# Altium schematic sheet Sensor_Box_Hub_SAMD21.SchDoc
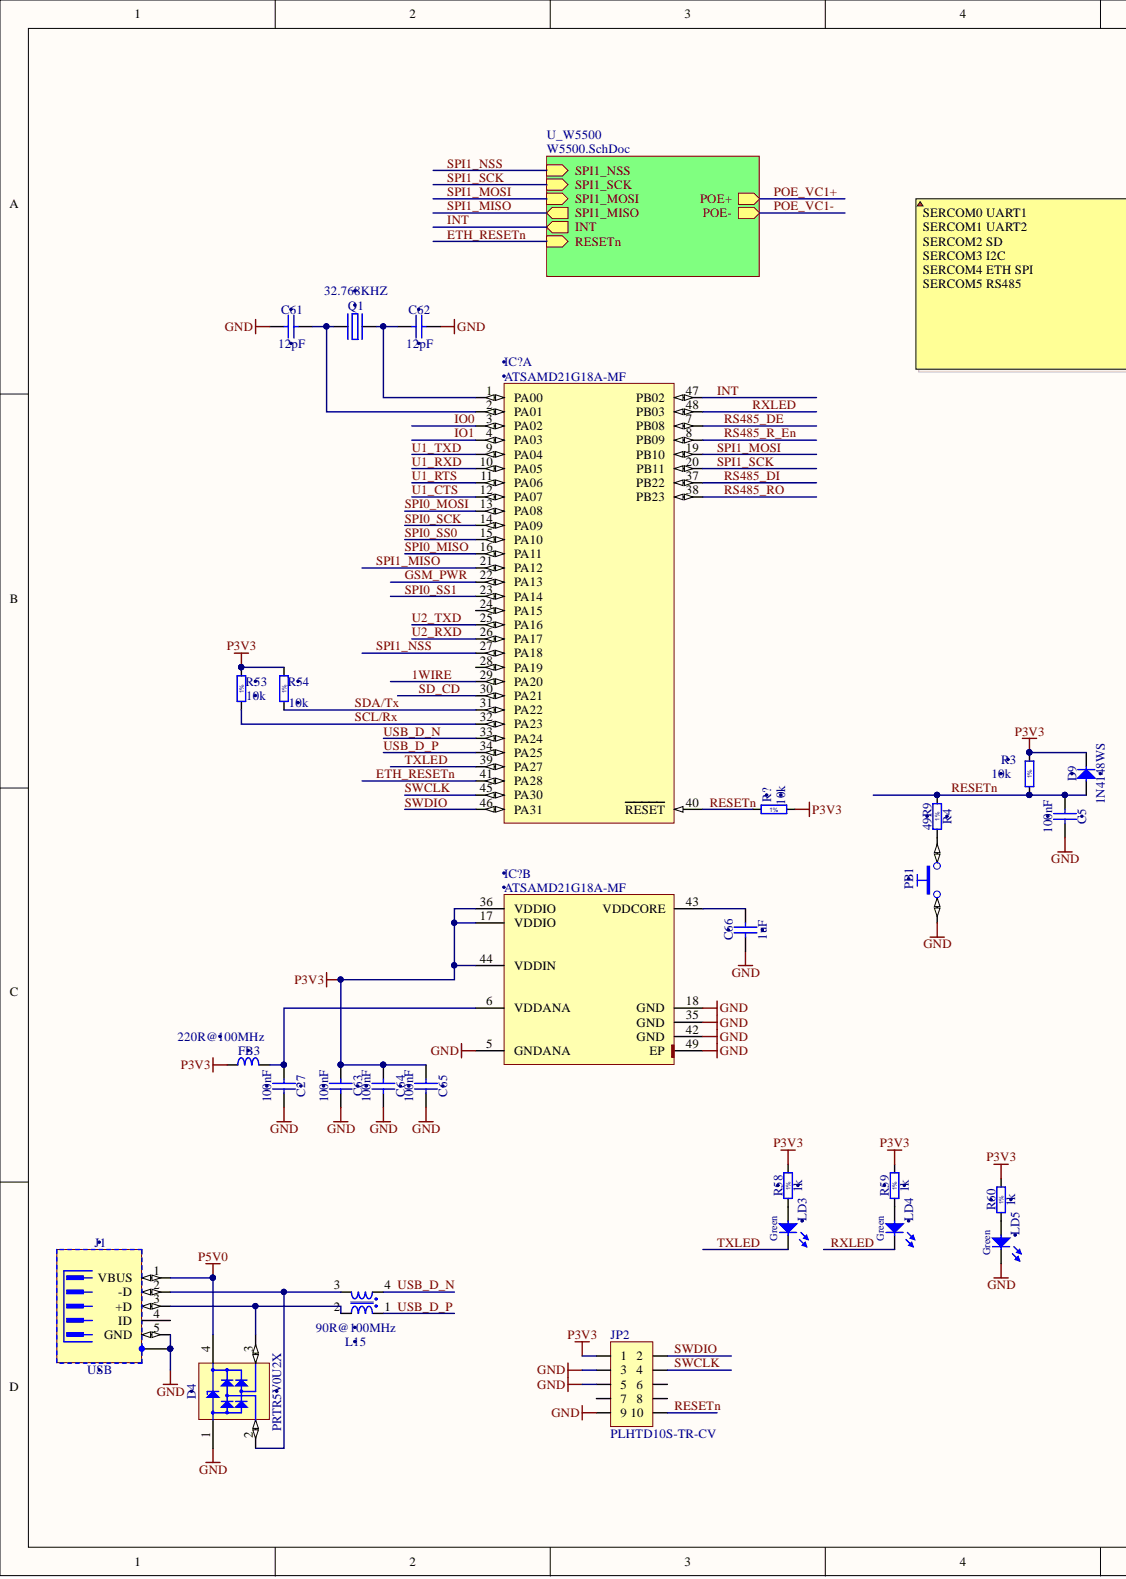
[diagram p0: Sensor_Box_Hub_SAMD21.SchDoc, left]
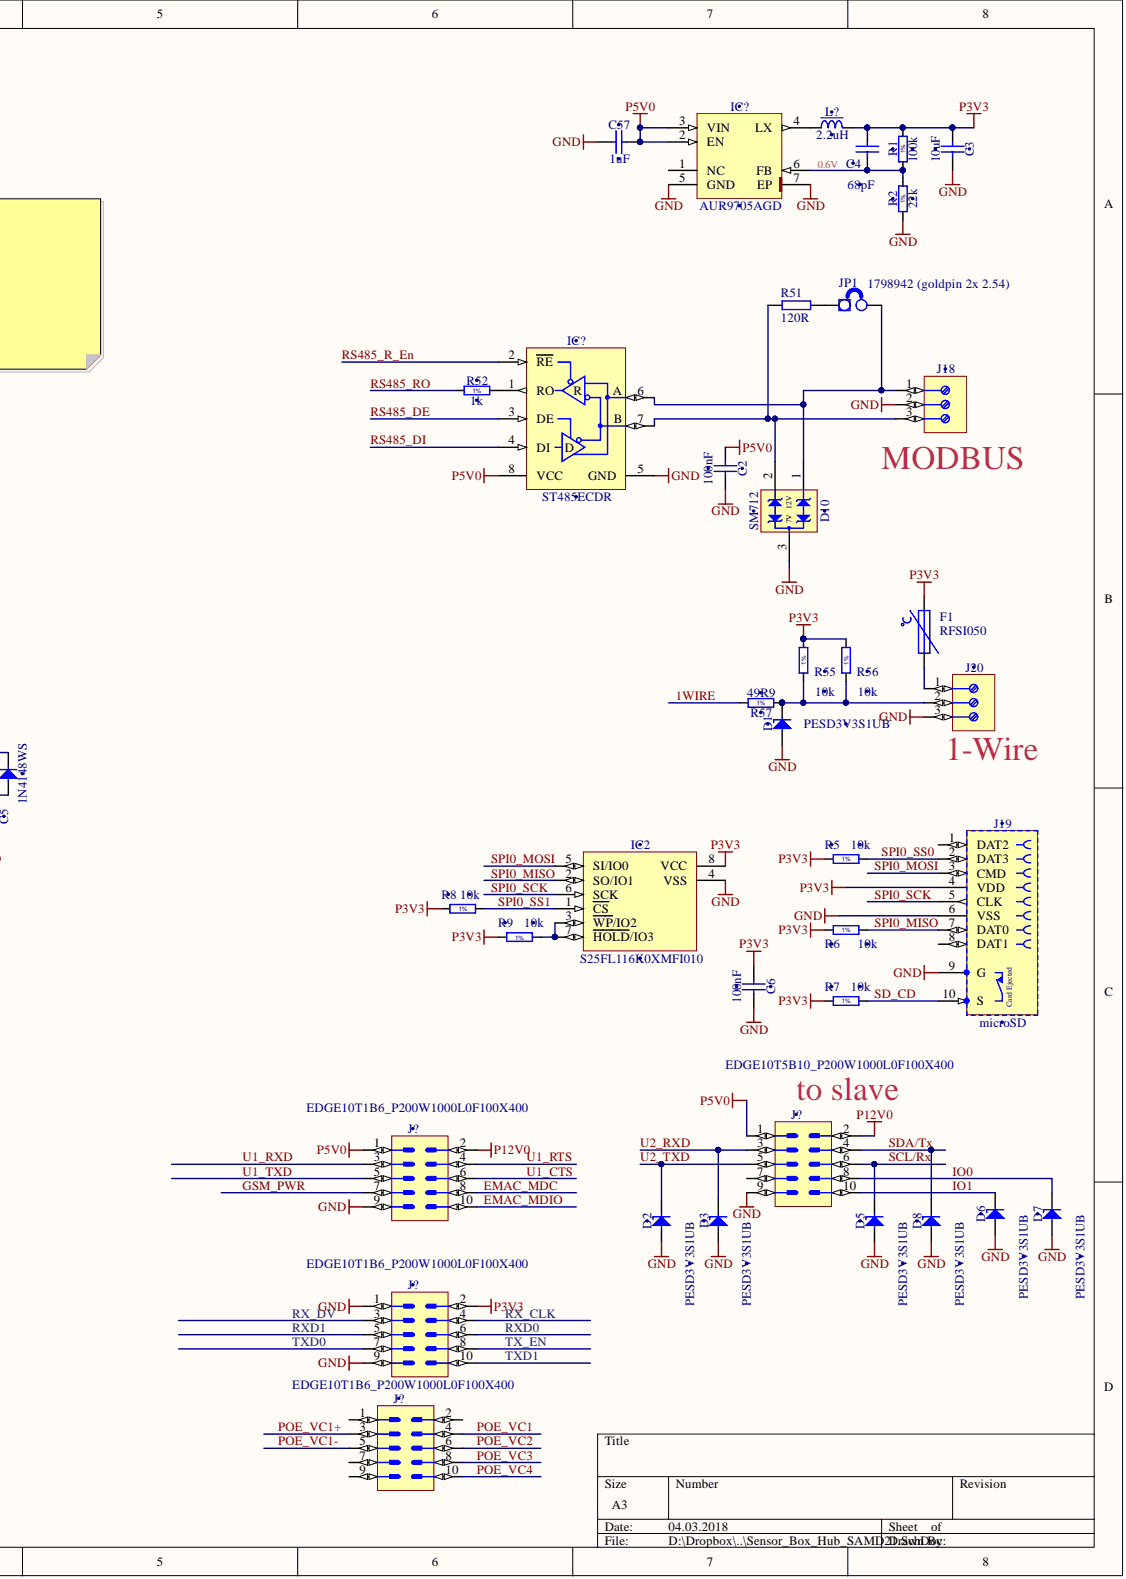
[diagram p1: Sensor_Box_Hub_SAMD21.SchDoc, right]

## Parts
C2  100nF  [CAPC1005X55N]
C3  10uF  [CAPC2012X135N]
C4  68pF  [CAPC1608X87N]
C5  100nF  [CAPC1005X55N]
C6  100nF  [CAPC1005X55N]
C27  100nF  [CAPC1005X55N]
C57  1uF  [CAPC1005X55N]
C61  12pF  [CAPC1005X55N]
C62  12pF  [CAPC1005X55N]
C63  100nF  [CAPC1005X55N]
C64  100nF  [CAPC1005X55N]
C65  100nF  [CAPC1005X55N]
C66  1uF  [CAPC1608X87N]
D1  PESD3V3S1UB  [SODFL1608X65N]
D2  PESD3V3S1UB  [SODFL1608X65N]
D3  PESD3V3S1UB  [SODFL1608X65N]
D4  PRTR5V0U2X  [SOT190P230X110-4N]
D5  PESD3V3S1UB  [SODFL1608X65N]
D6  PESD3V3S1UB  [SODFL1608X65N]
D7  PESD3V3S1UB  [SODFL1608X65N]
D8  PESD3V3S1UB  [SODFL1608X65N]
D9  1N4148WS  [SODFL2512X100N]
D10  SM712  [SOT95P237X112-3N]
F1  RFSI050  [0805R]
FB3  220R@100MHz  [INDC2012X105N]
IC2  S25FL116K0XMFI010  [SOIC127P790X216-8N]
IC?  ATSAMD21G18A-MF  [QFN50P700X700X100-49N-S525]  (parts A,B)
IC?
J1  USB  [MOLEX_105133-0011]
J18  Family  [PHOENIX_1725669]  (value not drawn)
J19  microSD  [ATTEND_112A-TAAR-R03]
J20  Family  [PHOENIX_1725669]  (value not drawn)
J?  Family  [EDGE10T5B10_P200W1000L0F100X400]  (value not drawn)  (no BOM)
J?  Family  [EDGE10T1B6_P200W1000L0F100X400]  (value not drawn)  (no BOM)
J?  Family  [EDGE10T1B6_P200W1000L0F100X400]  (value not drawn)  (no BOM)
J?  Family  [EDGE10T1B6_P200W1000L0F100X400]  (value not drawn)  (no BOM)
JP1  1798942 (goldpin 2x 2.54)  Supplier Part Number 1: 1798942  [jump]
JP2  PLHTD10S-TR-CV  [PLHTD10S-TR-CV]
L15  90R@100MHz  [IND_MURATA_DLW21S]
L?  2.2uH  [IND_MURATA_LQH44PN_P0]
LD3  Green  [LED_KINGBRIGHT_KPTR3216]
LD4  Green  [LED_KINGBRIGHT_KPTR3216]
LD5  Green  [LED_KINGBRIGHT_KPTR3216]
PB1  Push Button  [PB_TYCO_FSMSM]  (value not drawn)
Q1  32.768KHZ  [XTAL_ABRACON_ABS09]
R1  100k  [RESC1005X40N]
R2  22k  [RESC1005X40N]
R3  10k  [RESC1005X40N]
R4  49R9  [RESC1005X40N]
R5  10k  [RESC1005X40N]
R6  10k  [RESC1005X40N]
R7  10k  [RESC1005X40N]
R8  10k  [RESC1005X40N]
R9  10k  [RESC1005X40N]
R51  120R  [1206R]
R52  1k  [RESC1005X40N]
R53  10k  [RESC1005X40N]
R54  10k  [RESC1005X40N]
R55  10k  [RESC1005X40N]
R56  10k  [RESC1005X40N]
R57  49R9  [RESC1005X40N]
R58  1k  [RESC1005X40N]
R59  1k  [RESC1005X40N]
R60  1k  [RESC1005X40N]
R?  10k  [RESC1005X40N]

## Nets
GND: C2.2 C3.2 C5.2 C6.2 C27.2 C57.1 C61.1 C62.2 C63.2 C64.2 C65.2 C66.1 D1.2 D2.2 D3.2 D4.1 D5.2 D6.2 D7.2 D8.2 D10.3 IC2.4(VSS) IC?.5 IC?.7 IC?.18(GND) IC?.35(GND) IC?.42(GND) IC?.49(EP) J1.5(GND) J1.6 J18.2 J19.6(VSS) J19.9(G) J20.3 J?.1 J?.9 JP2.3 JP2.5 JP2.9 LD5.1 PB1.1 R2.1
P3V3: C3.1 C4.2 C6.1 C63.1 C64.1 C65.1 D9.1 F1.2 FB3.1 IC2.8(VCC) IC?.17(VDDIO) IC?.36(VDDIO) IC?.44(VDDIN) J19.4(VDD) J?.2 JP2.1 L?.2 R1.2 R3.2 R5.2 R6.2 R7.2 R8.2 R9.2 R53.2 R54.2 R55.2 R56.2 R58.2 R59.2 R60.2 R?.1
P5V0: C2.1 C57.2 D4.4 IC?.2 IC?.3 IC?.8 J1.1(VBUS) J?.1
P12V0: J?.2
1WIRE: IC?.29(PA20) R57.2
EMAC_MDC: J?.8
EMAC_MDIO: J?.10
ETH_RESETn: IC?.41(PA28)
GSM_PWR: IC?.22(PA13) J?.7
INT: IC?.47(PB02)
IO0: D7.1 IC?.3 J?.8
IO1: D6.1 IC?.4 J?.10
POE_VC1: J?.4
POE_VC1+: J?.3
POE_VC1-: J?.5
POE_VC2: J?.6
POE_VC3: J?.8
POE_VC4: J?.10
RESETn: C5.1 D9.2 IC?.40(RESET) JP2.10 R3.1 R4.1 R?.2
RS485_DE: IC?.3 IC?.7
RS485_DI: IC?.4 IC?.37(PB22)
RS485_R_En: IC?.2 IC?.8
RS485_RO: IC?.38(PB23) R52.1
RX_CLK: J?.4
RX_DV: J?.3
RXD0: J?.6
RXD1: J?.5
RXLED: IC?.48(PB03) LD4.1
SCL/Rx: D5.1 IC?.32(PA23) J?.6 R53.1
SD_CD: IC?.30(PA21) J19.10(S) R7.1
SDA/Tx: D8.1 IC?.31(PA22) J?.4 R54.1
SPI0_MISO: IC2.2(SO/IO1) IC?.16(PA11) J19.7(DAT0) R6.1
SPI0_MOSI: IC2.5(SI/IO0) IC?.13(PA08) J19.3(CMD)
SPI0_SCK: IC2.6(SCK) IC?.14(PA09) J19.5(CLK)
SPI0_SS0: IC?.15(PA10) J19.2(DAT3) R5.1
SPI0_SS1: IC2.1(CS) IC?.23(PA14) R8.1
SPI1_MISO: IC?.21(PA12)
SPI1_MOSI: IC?.19(PB10)
SPI1_NSS: IC?.27(PA18)
SPI1_SCK: IC?.20(PB11)
SWCLK: IC?.45(PA30) JP2.4
SWDIO: IC?.46(PA31) JP2.2
TX_EN: J?.8
TXD0: J?.7
TXD1: J?.10
TXLED: IC?.39(PA27) LD3.1
U1_CTS: IC?.12(PA07) J?.6
U1_RTS: IC?.11(PA06) J?.4
U1_RXD: IC?.10(PA05) J?.3
U1_TXD: IC?.9(PA04) J?.5
U2_RXD: D3.1 IC?.26(PA17) J?.3
U2_TXD: D2.1 IC?.25(PA16) J?.5
USB_D_N: IC?.33(PA24) L15.4
USB_D_P: IC?.34(PA25) L15.1
NetC4_1: C4.1 IC?.6 R1.1 R2.2
NetC27_1: C27.1 FB3.2 IC?.6
NetC61_2: C61.2 IC?.2 Q1.1
NetC62_1: C62.1 IC?.1 Q1.2
NetC66_2: C66.2 IC?.43(VDDCORE)
NetD1_1: D1.1 J20.2 R55.1 R56.1 R57.1
NetD4_2: D4.2 J1.2(-D) L15.3
NetD4_3: D4.3 J1.3(+D) L15.2
NetD10_1: D10.1 IC?.6 J18.1 JP1.2
NetD10_2: D10.2 IC?.7 J18.3 R51.1
NetF1_1: F1.1 J20.1
NetIC2_3: IC2.3(WP/IO2) IC2.7(HOLD/IO3) R9.1
NetIC?_1: IC?.1 R52.2
NetIC?_4: IC?.4 L?.1
NetIC?_24: IC?.24(PA15)
NetIC?_28: IC?.28(PA19)
NetJ1_4: J1.4(ID)
NetJ19_1: J19.1(DAT2)
NetJ19_8: J19.8(DAT1)
NetJ?_1: J?.1
NetJ?_2: J?.2
NetJ?_7: J?.7
NetJ?_9: J?.9
NetJP1_1: JP1.1 R51.2
NetJP2_6: JP2.6
NetJP2_7: JP2.7
NetJP2_8: JP2.8
NetLD3_2: LD3.2 R58.1
NetLD4_2: LD4.2 R59.1
NetLD5_2: LD5.2 R60.1
NetPB1_2: PB1.2 R4.2

## Library data of the parts
C2 C5 C6 C27 C63-C65 = CC0402_100NF_16V_10%_X7R: Non-polarised capacitor; Manufacturer: GENERIC; Manufacturer1 Part Number: GRM155R71C104KA88D; Part Description: SMD Multilayer Chip Ceramic Capacitor; Database Table Name: Capacitors SMD; TC: X7R; Tolerance: ±10%; Voltage: 16V; Case: 0402; Component Kind: Standard; Component Type: Standard; CreateDate: 05.12.2013; HelpURL: \\cern.ch\dfs\Applications\Altium\Datasheets\CC0402_X7R_MURATA_GRM.pdf; LatestRevisionDate: 03.12.2007; Library Name: Capacitors.DbLib; Manufacturer1 ComponentHeight: 0.55mm; Manufacturer1 Example: MURATA; Manufacturer Part Number: CC0402_100NF_16V_10%_X7R; Mounted: Yes; Part Number: CC0402_100NF_16V_10%_X7R; Pin Count: 2; Sense: No; SMD: Yes; Socket: No; Status: Not Recommended; Value: 100nF; Author: CERN DEM JLC
C3 = CC0805_10UF_16V_10%_X5R: Non-polarised capacitor; Manufacturer: GENERIC; Manufacturer1 Part Number: C0805C106K4PAC; Part Description: SMD Multilayer Chip Ceramic Capacitor; Database Table Name: Capacitors SMD; TC: X5R; Tolerance: ±10%; Voltage: 16V; Case: 0805; Component Kind: Standard; Component Type: Standard; CreateDate: 03.12.2007; HelpURL: \\cern.ch\dfs\Applications\Altium\Datasheets\CC1206_X5R_KEMET.pdf; LatestRevisionDate: 03.12.2007; Library Name: Capacitors.DbLib; Manufacturer1 ComponentHeight: 1.4mm; Manufacturer1 Example: KEMET; Manufacturer Part Number: CC0805_10UF_16V_10%_X5R; Mounted: Yes; Part Number: CC0805_10UF_16V_10%_X5R; Pin Count: 2; Sense: No; SMD: Yes; Socket: No; Status: Preferred; Value: 10uF; Author: CERN DEM JLC
C4 = CC0603_68PF_50V_5%_NP0: Non-polarised capacitor; Manufacturer: GENERIC; Manufacturer1 Part Number: 223886715689; Part Description: SMD Multilayer Chip Ceramic Capacitor; Database Table Name: Capacitors SMD; TC: C0G/NP0; Tolerance: ±5%; Voltage: 50V; Case: 0603; Component Kind: Standard; Component Type: Standard; CreateDate: 03.12.2007; HelpURL: \\cern.ch\dfs\Applications\Altium\Datasheets\CC0603_NP0_PHYCOMP.pdf; LatestRevisionDate: 03.12.2007; Library Name: Capacitors.DbLib; Manufacturer1 ComponentHeight: 0.87mm; Manufacturer1 Example: PHYCOMP; Manufacturer Part Number: CC0603_68PF_50V_5%_NP0; Mounted: Yes; Part Number: CC0603_68PF_50V_5%_NP0; Pin Count: 2; Sense: No; SMD: Yes; Socket: No; Status: Preferred; Value: 68pF; Author: CERN DEM JLC
C57 = CC0402_1UF_16V_10%_X5R: Non-polarised capacitor; Manufacturer: GENERIC; Manufacturer1 Part Number: C1005X5R1C105KT; Part Description: SMD Multilayer Chip Ceramic Capacitor; Database Table Name: Capacitors SMD; TC: X5R; Tolerance: ±10%; Voltage: 16V; Case: 0402; Component Kind: Standard; Component Type: Standard; CreateDate: 25.10.2012; HelpURL: \\cern.ch\dfs\Applications\Altium\Datasheets\CC0402_X5R_TDK_C.pdf; LatestRevisionDate: 25.10.2012; Library Name: Capacitors.DbLib; Manufacturer1 ComponentHeight: 0.55mm; Manufacturer1 Example: TDK; Manufacturer Part Number: CC0402_1UF_16V_10%_X5R; Mounted: Yes; Part Number: CC0402_1UF_16V_10%_X5R; Pin Count: 2; Sense: No; SMD: Yes; Socket: No; Status: Preferred; Value: 1uF; Author: CERN DEM JLC
C61 C62 = CC0402_12PF_50V_5%_C0G: Non-polarised capacitor; Manufacturer: GENERIC; Manufacturer1 Part Number: C0402C120J5GACTU; Part Description: SMD Multilayer Chip Ceramic Capacitor; Database Table Name: Capacitors SMD; TC: C0G/NP0; Tolerance: ±5%; Voltage: 50V; Case: 0402; Component Kind: Standard; Component Type: Standard; CreateDate: 04.10.2016; HelpURL: \\cern.ch\dfs\Applications\Altium\Datasheets\CC0805_C0G_KEMET_(Commercial Grade).pdf; LatestRevisionDate: 04.10.2016; Library Name: Capacitors.DbLib; Manufacturer1 ComponentHeight: 0.55mm; Manufacturer1 Example: KEMET; Manufacturer Part Number: CC0402_12PF_50V_5%_C0G; Mounted: Yes; Part Number: CC0402_12PF_50V_5%_C0G; Pin Count: 2; Sense: No; SMD: Yes; Socket: No; Status: Preferred; Value: 12pF; Author: CERN DEM JLC
C66 = CC0603_1UF_16V_10%_X7R: Non-polarised capacitor; Manufacturer: GENERIC; Manufacturer1 Part Number: 223878615663; Part Description: SMD Multilayer Chip Ceramic Capacitor; Database Table Name: Capacitors SMD; TC: X7R; Tolerance: ±10%; Voltage: 16V; Case: 0603; Component Kind: Standard; Component Type: Standard; CreateDate: 03.12.2007; HelpURL: \\cern.ch\dfs\Applications\Altium\Datasheets\CC0603_X7R_PHYCOMP.pdf; LatestRevisionDate: 17.04.2015; Library Name: Capacitors.DbLib; Manufacturer1 ComponentHeight: 0.9mm; Manufacturer1 Example: YAGEO PHYCOMP; Manufacturer Part Number: CC0603_1uF_16V_10%_X7R; Mounted: Yes; Part Number: CC0603_1UF_16V_10%_X7R; Pin Count: 2; Sense: No; SMD: Yes; Socket: No; Status: None; Value: 1uF; Author: CERN DEM JLC
D1-D3 D5-D8 = PESD3V3S1UB: ESD protection diode; Manufacturer: NXP SEMICONDUCTORS; Part Description: ESD protection diode (23kV); Bonding: No; Database Table Name: Diodes; Power: 330W; PressFit: No; Voltage: 3V3; Case: SOD523; ComponentHeight: 0.65mm; Component Kind: Standard; Component Type: Standard; CreateDate: 29.11.2012; Device: PESD3V3S1UB; HelpURL: \\cern.ch\dfs\Applications\Altium\Datasheets\PESD3V3S1UB.pdf; LatestRevisionDate: 29.11.2012; Library Name: ICs And Semiconductors.DbLib; Manufacturer Part Number: PESD3V3S1UB; Mounted: Yes; PackageDescription: Ultra Small Outline Diode Flat Lead (SOD-523), Body; 1.2mm L X 0.8mm W X 0.65mm H, IPC Medium Density; Part Number: PESD3V3S1UB; Pin Count: 2; Sense: No; SMD: Yes; Socket: No; Author: CERN DEM JLC
D4 = PRTR5V0U2X: Ultra Low Capacitance Double Rail-to-Rail ESD Protection Diode; Manufacturer: NXP SEMICONDUCTORS; Part Description: Ultra Low Capacitance (1pF) Double Rail-to-Rail ESD Protection Diode (8kV); Bonding: No; Database Table Name: Diodes; PressFit: No; Voltage: 5.5V; Case: SOT143B; ComponentHeight: 1.1mm; Component Kind: Standard; Component Type: Standard; CreateDate: 2011-03-22; Device: PRTR5V0U2X; HelpURL: \\cern.ch\dfs\Applications\Altium\Datasheets\PRTR5V0U2X.pdf; LatestRevisionDate: 2011-03-22; Library Name: ICs And Semiconductors.DbLib; Manufacturer Part Number: PRTR5V0U2X; Mounted: Yes; PackageDescription: SOT143B, SOT, 1.90mm Pitch; 4 Pin, 1.30mm W X 2.90mm L X 1.10mm H body, IPC Medium Density; Part Number: PRTR5V0U2X; Pin Count: 4; SMD: Yes; Socket: No; Table: Diodes; Author: CERN DEM JLC
D9 = 1N4148WS: Diode; Manufacturer: FAIRCHILD SEMICONDUCTOR; Part Description: Small Signal Diodes; Bonding: No; Database Table Name: Diodes; Power: 150mA; PressFit: No; Voltage: 75V; Case: SOD-323F; ComponentHeight: 1mm; Component Kind: Standard; Component Type: Standard; CreateDate: 12.05.2011; Device: 1N4148WS; HelpURL: \\cern.ch\dfs\Applications\Altium\Datasheets\1N4148WS.pdf; LatestRevisionDate: 12.05.2011; Library Name: ICs And Semiconductors.DbLib; Manufacturer Part Number: 1N4148WS; Mounted: Yes; PackageDescription: SODFL Diode; 1.70mm W X 1.25mm L X 1.00mm H body, IPC Medium Density; Part Number: 1N4148WS; Pin Count: 2; Sense: No; SMD: Yes; Socket: No; Author: CERN DEM JLC
D10 = SM712: Asymmetrical TVS Diode for Extended Common-Mode RS-485; Manufacturer: SEMTECH; Bonding: No; Database Table Name: Diodes; Power: 400W; PressFit: No; Voltage: 12V/-7V; Case: SOT-23; ComponentHeight: 1.12mm; Component Kind: Standard; Component Type: Standard; CreateDate: 23.04.2012; Device: SM712; HelpURL: \\cern.ch\dfs\Applications\Altium\Datasheets\SM712.pdf; LatestRevisionDate: 23.04.2012; Library Name: ICs And Semiconductors.DbLib; Manufacturer Part Number: SM712; Mounted: Yes; PackageDescription: SOT, 0.95mm, pitch; 3 pin,1.30mm W X 2.92mm L X 1.12mm H body, IPC Medium Density; Part Description: Asymmetrical TVS Diode for Extended Common-Mode RS-485; Part Number: SM712; Pin Count: 3; Sense: No; SMD: Yes; Socket: No; Status: Obsolete; Author: CERN DEM JLC
F1 = F_PTC_0.05A: 50mA polymer FUSE; Component Kind: Standard; Library Name: LIBRARY_SCH.SCHLIB
FB3 = IND0805_MURATA_BLM21PG221SN1D: Inductor; Manufacturer: MURATA; Part Description: SMD EMIFIL Suppression Chip Ferrite Bead; Database Table Name: Inductors SMD; Power: 2A; Resistance: 0.05R; Tolerance: ±25%; Case: 0805; ComponentHeight: 1.05mm; Component Kind: Standard; Component Type: Standard; CreateDate: 2010-01-25; HelpURL: \\cern.ch\dfs\Applications\Altium\Datasheets\IND0402_MURATA_BLM21P.pdf; LatestRevisionDate: 2010-01-25; Library Name: Inductors & Transformers.DbLib; Manufacturer Part Number: BLM21PG221SN1D; Mounted: Yes; Part Number: IND0805_MURATA_BLM21PG221SN1D; Pin Count: 2; SMD: Yes; Socket: No; Status: None; Table: Inductors SMD; Value: 220R@100MHz; Author: CERN DEM JLC
IC2 = (no symbol name): 16Mb, 3V, Multiple I/O Serial NOR Flash Memory; Part Description: 16Mb, 2.7 to 3.6V, SPI Flash Memory; Bonding: No; PressFit: No; Case: SOIC8; ComponentHeight: 2.16mm; Component Kind: Standard; Component Type: Standard; CreateDate: 28.11.2011; Device: S25FL116K0XMFI010; HelpURL: \\cern.ch\dfs\Applications\Altium\Datasheets\N25Q128A13ESE40.pdf; LatestRevisionDate: 30.07.2015; Library Name: LIBRARY_SCH.SCHLIB; Manufacturer Part Number: S25FL116K0XMFI010; Mounted: Yes; PackageDescription: SOIC, 1.27mm Pitch; 8 Pin, 5.285mm W X 5.335mm L X 2.16mm H body, IPC Medium Density; Part Number: S25FL116K0XMFI010; Pin Count: 8; Sense: No; SMD: Yes; Socket: No; Author: GK
IC? = ATSAMD21G18A-MF: 32-bit ARM®-based Cortex®-M0+ core Microcontroller, 1.62V to 3.6V, 48MHz, 256KB Flash, 32KB of SRAM, 48 QFN, Industrial Grade (-40°C to 125°C); Manufacturer: MICROCHIP; Part Description: 32-bit ARM®-based Cortex®-M0+ core Microcontroller, 1.62V to 3.6V, 48MHz, 256KB Flash, 32KB SRAM, 48 QFN, Temperature Range -40°C to 125°C; Bonding: No; Database Table Name: Logic; PressFit: No; Case: QFN48; ComponentHeight: 1mm; Component Kind: Standard; Component Type: Standard; CreateDate: 23.01.2018; Device: ATSAMD21G18A-MF; HelpURL: \\cern.ch\dfs\Applications\Altium\Datasheets\ATSAMD21G18A-MF.pdf; LatestRevisionDate: 23.01.2018; Library Name: ICs And Semiconductors.DbLib; Manufacturer Part Number: ATSAMD21G18A-MF; Mounted: Yes; PackageDescription: QFN, 0.50mm Pitch, Square; 48 Pin, 7.00mm L X 7.00mm W X 1mm H Body (W/Thermal Tab 5.25mm X 5.25mm), IPC Medium Density; Part Number: ATSAMD21G18A-MF; Pin Count: 49; Sense: No; SMD: Yes; Socket: No; Author: CERN DEM BC
J1 = MOLEX_105133-0011: 5 Pin + 1 Shield USB Receptacle; Part Description: 0.65mm Pitch, Micro-USB Type B Surface Mounte Vertical Receptacle; Database Table Name: MOLEX; PressFit: No; ComponentHeight: 6.7mm; Component Kind: Standard; Component Type: Standard; CreateDate: 13.12.2017; Family: USB; HelpURL: \\cern.ch\dfs\Applications\Altium\Datasheets\MOLEX_105133-0011.pdf; LatestRevisionDate: 13.12.2017; Library Name: Connectors.DbLib; Manufacturer: MOLEX; Manufacturer Part Number: 105133-0011; Mounted: Yes; Part Number: MOLEX_105133-0011; Pin Count: 8; Sense: No; SMD: Yes; Socket: No; Status: None; Author: CERN DEM MR
J18 J20 = PHOENIX_1725669: Terminal Block, 3 Positions, Screw Connection; Manufacturer: PHOENIX CONTACT; Manufacturer Part Number: 1725669 (MPT 0,5/3-2,54); Part Description: 6A, 63V, Terminal Block, Pitch 2.54mm, 3 Positions, Screw Connection; PressFit: No; ComponentHeight: 8.5mm; Component Kind: Standard; Component Type: Standard; CreateDate: 07.11.2008; Database Table Name: PHOENIX; HelpURL: \\cern.ch\dfs\Applications\Altium\Datasheets\PHOENIX_1725669.pdf; LatestRevisionDate: 26.04.2010; Library Name: Connectors.DbLib; Mounted: Yes; Part Number: PHOENIX_1725669; Pin Count: 3; Sense: No; SMD: No; Socket: No; Status: None; Author: CERN DEM JMW
J19 = ATTEND_112A-TAAR-R03: Connector MicroSD 8 Pin + Switch; Manufacturer: ATTEND; Part Description: MicroSD Socket, Push-Push Type; Database Table Name: Miscellaneous; PressFit: No; ComponentHeight: 1.9mm; Component Kind: Standard; Component Type: Standard; CreateDate: 07.07.2015; Family: microSD; HelpURL: \\cern.ch\dfs\Applications\Altium\Datasheets\ATTEND_112A-TAAR-R03.pdf; LatestRevisionDate: 07.07.2015; Library Name: Connectors.DbLib; Manufacturer Part Number: 112A-TAAR-R03; Mounted: Yes; Part Number: ATTEND_112A-TAAR-R03; Pin Count: 10; Sense: No; SMD: Yes; Socket: No; Status: None; Author: CERN DEM JMW
J? = EDGE10T5B10_P200W1000L0F100X400: PCB Edge Connector 10 (2x5); Part Description: 10 Contacts Board Edge Connector, Pitch 2mm, Length 0mm, Width 10mm, Finger Lenght 4mm, Finger Width 1mm; Database Table Name: Miscellaneous; Mounted: No; PressFit: No; ComponentHeight: 0mm; Component Type: Standard (No BOM); CreateDate: 14.02.2018; HelpURL: \\cern.ch\dfs\Applications\Altium\Datasheets\EDGE10T5B10_P200W1000L0F100X400.pdf; LatestRevisionDate: 14.02.2018; Library Name: Connectors.DbLib; Part Number: EDGE10T5B10_P200W1000L0F100X400; Pin Count: 10; Sense: No; SMD: No; Socket: No; Status: None; Author: CERN DEM MR
J? J? J? = EDGE10T1B6_P200W1000L0F100X400: PCB Edge Connector 10 (2x5); Part Description: 10 Contacts Board Edge Connector, Pitch 2mm, Length 0mm, Width 10mm, Finger Lenght 4mm, Finger Width 1mm; Database Table Name: Miscellaneous; Mounted: No; PressFit: No; ComponentHeight: 0mm; Component Type: Standard (No BOM); CreateDate: 14.02.2018; HelpURL: \\cern.ch\dfs\Applications\Altium\Datasheets\EDGE10T1B6_P200W1000L0F100X400.pdf; LatestRevisionDate: 14.02.2018; Library Name: Connectors.DbLib; Part Number: EDGE10T1B6_P200W1000L0F100X400; Pin Count: 10; Sense: No; SMD: No; Socket: No; Status: None; Author: CERN DEM MR
JP1 = JUMPER: Component Kind: Standard; Description: Jumper; Library Name: LIBRARY_SCH.SCHLIB; Supplier 1: Farnell
JP2 = CON_2x5 1.27 SMT Male: 1.27 mm dual row goldpin (Maritex); Component Kind: Standard; Library Name: LIBRARY_SCH.SCHLIB
L15 = IND_MURATA_DLW21SN900SQ2L: Inductor Common Mode; Manufacturer: MURATA; Part Description: Wire Wound Common Mode Choke Coil (DLW21S Series); Database Table Name: Inductors SMD; Power: 330mA; Resistance: 0.35R; Tolerance: ±25%; Case: 0805; ComponentHeight: 1.4mm; Component Kind: Standard; Component Type: Standard; CreateDate: 2014-07-01; HelpURL: \\cern.ch\dfs\Applications\Altium\Datasheets\IND_MURATA_DLW21S.pdf; LatestRevisionDate: 2014-07-01; Library Name: Inductors & Transformers.DbLib; Manufacturer Part Number: DLW21SN900SQ2L; Mounted: Yes; Part Number: IND_MURATA_DLW21SN900SQ2L; Pin Count: 4; SMD: Yes; Socket: No; Status: None; Table: Inductors SMD; Value: 90R@100MHz; Author: CERN DEM JLC
L? = IND_2.2UH_20%_MURATA_LQH44PN2R2MP0L: Inductor With Core; Manufacturer: MURATA; Part Description: Wire Wound Ferrite Inductor for Power Lines; Database Table Name: Inductors SMD; Power: 1.8A; Resistance: 0R049; Tolerance: ±20%; Case: 4040; ComponentHeight: 1.8mm; Component Kind: Standard; Component Type: Standard; CreateDate: 14.02.2018; HelpURL: \\cern.ch\dfs\Applications\Altium\Datasheets\IND_MURATA_LQH44PN_P0.pdf; LatestRevisionDate: 14.02.2018; Library Name: Inductors & Transformers.DbLib; Manufacturer Part Number: LQH44PN2R2MP0L; Mounted: Yes; Part Number: IND_2.2UH_20%_MURATA_LQH44PN2R2MP0L; Pin Count: 2; Sense: No; SMD: Yes; Socket: No; Status: None; Value: 2.2uH; Author: CERN DEM JLC
LD3-LD5 = LED_KINGBRIGHT_KPTR-3216CGCK: LED Green 1A 2C; Part Description: Reverse Mount LED 1.4x1.6mm Green; Bonding: No; Database Table Name: LEDs & Displays; PressFit: No; Color: Green; ComponentHeight: 0.35mm; Component Kind: Standard; Component Type: Standard; CreateDate: 15.02.2016; HelpURL: \\cern.ch\dfs\Applications\Altium\Datasheets\LED_KINGBRIGHT_KPTR-3216CGCK.pdf; LatestRevisionDate: 15.02.2016; Library Name: ICs And Semiconductors.DbLib; Manufacturer: KINGBRIGHT; Manufacturer Part Number: KPTR-3216CGCK; Mounted: Yes; PackageDescription: Reverse Mount LED 3216, Hole 2.1x2.3mm 0.35mm Height; Part Number: LED_KINGBRIGHT_KPTR-3216CGCK; Pin Count: 2; Sense: No; SMD: Yes; Socket: No; Author: CERN DEM MR
PB1 = PB_TYCO_FSMSM: Push-Button Switch SPDT; Manufacturer: TYCO ELECTRONICS; Manufacturer Part Number: FSMSM or 1437566-3; Part Description: 12VDC 50mA SPST Tactile  Push-Button Switch; Database Table Name: Switches; PressFit: No; ComponentHeight: 4.3mm; Component Kind: Standard; Component Type: Standard; CreateDate: 30.06.2008; Family: Push Button; HelpURL: \\cern.ch\dfs\Applications\Altium\Datasheets\PB_TYCO_FSMSM.pdf; LatestRevisionDate: 21.06.2012; Library Name: Eletro-mechanical.DbLib; Mounted: Yes; PackageDescription: Body 3.5x6.0mm, Pushbutton Switch,  TYCO ALCOSWITCH FSMSM Serie; Part Number: PB_TYCO_FSMSM; Pin Count: 2; Sense: No; SMD: Yes; Socket: No; Status: None; Author: CERN DEM JLC
Q1 = XTAL_32.768KHZ_ABRACON_ABS09-32.768KHZ-T: Quartz Crystal; Part Description: Tolerance: ±20ppm, Stability: ±5ppm, -40°C to +85°C, 12.5pF, SMD Low Profile Crystal, ABS09 Series; Bonding: No; Database Table Name: Crystals & Oscillators; PressFit: No; ComponentHeight: 0.9mm; Component Kind: Standard; Component Type: Standard; CreateDate: 16.10.2014; HelpURL: \\cern.ch\dfs\Applications\Altium\Datasheets\XTAL_ABRACON_ABS09.pdf; LatestRevisionDate: 16.10.2014; Library Name: ICs And Semiconductors.DbLib; Manufacturer: ABRACON; Manufacturer Part Number: ABS09-32.768KHZ-T; Mounted: Yes; PackageDescription: 2 Pins, 4.1x1.5mm, SMD Low Profile Crystal, ABS09 Serie; Part Number: XTAL_32.768KHZ_ABRACON_ABS09-32.768KHZ-T; Pin Count: 2; Sense: No; SMD: Yes; Socket: No; Value: 32.768KHZ; Author: CERN DEM JLC
R1 = R0402_100K_1%_0.0625W_100PPM: Resistor - 1%; Manufacturer: GENERIC; Manufacturer1 Part Number: 232270671004L; Part Description: General Purpose Thick Film Chip Resistor; Database Table Name: Resistors SMD; PressFit: No; TC: ±100ppm/°C; Case: 0402; Component Kind: Standard; Component Type: Standard; CreateDate: 03.12.2007; HelpURL: \\cern.ch\dfs\Applications\Altium\Datasheets\R0402_Phycomp_RC0402.pdf; LatestRevisionDate: 17.10.2012; Library Name: Resistors.DbLib; Manufacturer1 ComponentHeight: 0.4mm; Manufacturer1 Example: YAGEO PHYCOMP; Manufacturer Part Number: R0402_100K_1%_0.0625W_100PPM; Mounted: Yes; Part Number: R0402_100K_1%_0.0625W_100PPM; Pin Count: 2; Power: 0.0625W; Sense: No; SMD: Yes; Socket: No; Status: Not Recommended; Tolerance: ±1%; Value: 100k; Author: CERN DEM JLC
R2 = R0402_22K_1%_0.0625W_100PPM: Resistor - 1%; Manufacturer: GENERIC; Manufacturer1 Part Number: 232270672203L; Part Description: General Purpose Thick Film Chip Resistor; Database Table Name: Resistors SMD; PressFit: No; TC: ±100ppm/°C; Case: 0402; Component Kind: Standard; Component Type: Standard; CreateDate: 03.12.2007; HelpURL: \\cern.ch\dfs\Applications\Altium\Datasheets\R0402_Phycomp_RC0402.pdf; LatestRevisionDate: 17.10.2012; Library Name: Resistors.DbLib; Manufacturer1 ComponentHeight: 0.4mm; Manufacturer1 Example: YAGEO PHYCOMP; Manufacturer Part Number: R0402_22K_1%_0.0625W_100PPM; Mounted: Yes; Part Number: R0402_22K_1%_0.0625W_100PPM; Pin Count: 2; Power: 0.0625W; Sense: No; SMD: Yes; Socket: No; Status: Not Recommended; Tolerance: ±1%; Value: 22k; Author: CERN DEM JLC
R3 R5-R9 R53-R56 R? = R0402_10K_1%_0.0625W_100PPM: Resistor - 1%; Manufacturer: GENERIC; Manufacturer1 Part Number: 232270671003L; Part Description: General Purpose Thick Film Chip Resistor; Database Table Name: Resistors SMD; TC: ±100ppm/°C; Case: 0402; Component Kind: Standard; Component Type: Standard; CreateDate: 2007-12-03; HelpURL: \\cern.ch\dfs\Applications\Altium\Datasheets\R0402_Phycomp_RC0402.pdf; LatestRevisionDate: 2012-10-17; Library Name: Resistors.DbLib; Manufacturer1 ComponentHeight: 0.4mm; Manufacturer1 Example: YAGEO PHYCOMP; Manufacturer Part Number: R0402_10K_1%_0.0625W_100PPM; Mounted: Yes; Part Number: R0402_10K_1%_0.0625W_100PPM; Pin Count: 2; Power: 0.0625W; SMD: Yes; Socket: No; Status: Not recommended; Table: Resistors SMD; Tolerance: ±1%; Value: 10k; Author: CERN DEM JLC
R4 R57 = R0402_49R9_1%_0.0625W_100PPM: Resistor - 1%; Manufacturer: GENERIC; Manufacturer1 Part Number: 232270674999L or RC0402FR-0749R9L; Part Description: General Purpose Thick Film Chip Resistor; Database Table Name: Resistors SMD; TC: ±100ppm/°C; Case: 0402; Component Kind: Standard; Component Type: Standard; CreateDate: 2012-10-24; HelpURL: \\cern.ch\dfs\Applications\Altium\Datasheets\R0402_Phycomp_RC0402.pdf; LatestRevisionDate: 2012-10-24; Library Name: Resistors.DbLib; Manufacturer1 ComponentHeight: 0.4mm; Manufacturer1 Example: YAGEO PHYCOMP; Manufacturer Part Number: R0402_49R9_1%_0.0625W_100PPM; Mounted: Yes; Part Number: R0402_49R9_1%_0.0625W_100PPM; Pin Count: 2; Power: 0.0625W; SMD: Yes; Socket: No; Status: Not recommended; Table: Resistors SMD; Tolerance: ±1%; Value: 49R9; Author: CERN DEM JLC
R51 = RES_1206: SMD Resistor; Component Kind: Standard; Library Name: LIBRARY_SCH.SCHLIB; Supplier 1: Newark; Supplier Part Number 1: 39K0871
R52 R58-R60 = R0402_1K_1%_0.0625W_100PPM: Resistor - 1%; Manufacturer: GENERIC; Manufacturer1 Part Number: 232270671002L; Part Description: General Purpose Thick Film Chip Resistor; Database Table Name: Resistors SMD; PressFit: No; TC: ±100ppm/°C; Case: 0402; Component Kind: Standard; Component Type: Standard; CreateDate: 03.12.2007; HelpURL: \\cern.ch\dfs\Applications\Altium\Datasheets\R0402_Phycomp_RC0402.pdf; LatestRevisionDate: 17.10.2012; Library Name: Resistors.DbLib; Manufacturer1 ComponentHeight: 0.4mm; Manufacturer1 Example: YAGEO PHYCOMP; Manufacturer Part Number: R0402_1K_1%_0.0625W_100PPM; Mounted: Yes; Part Number: R0402_1K_1%_0.0625W_100PPM; Pin Count: 2; Power: 0.0625W; Sense: No; SMD: Yes; Socket: No; Status: Not Recommended; Tolerance: ±1%; Value: 1k; Author: CERN DEM JLC
